# Altium schematic sheet Power.SchDoc
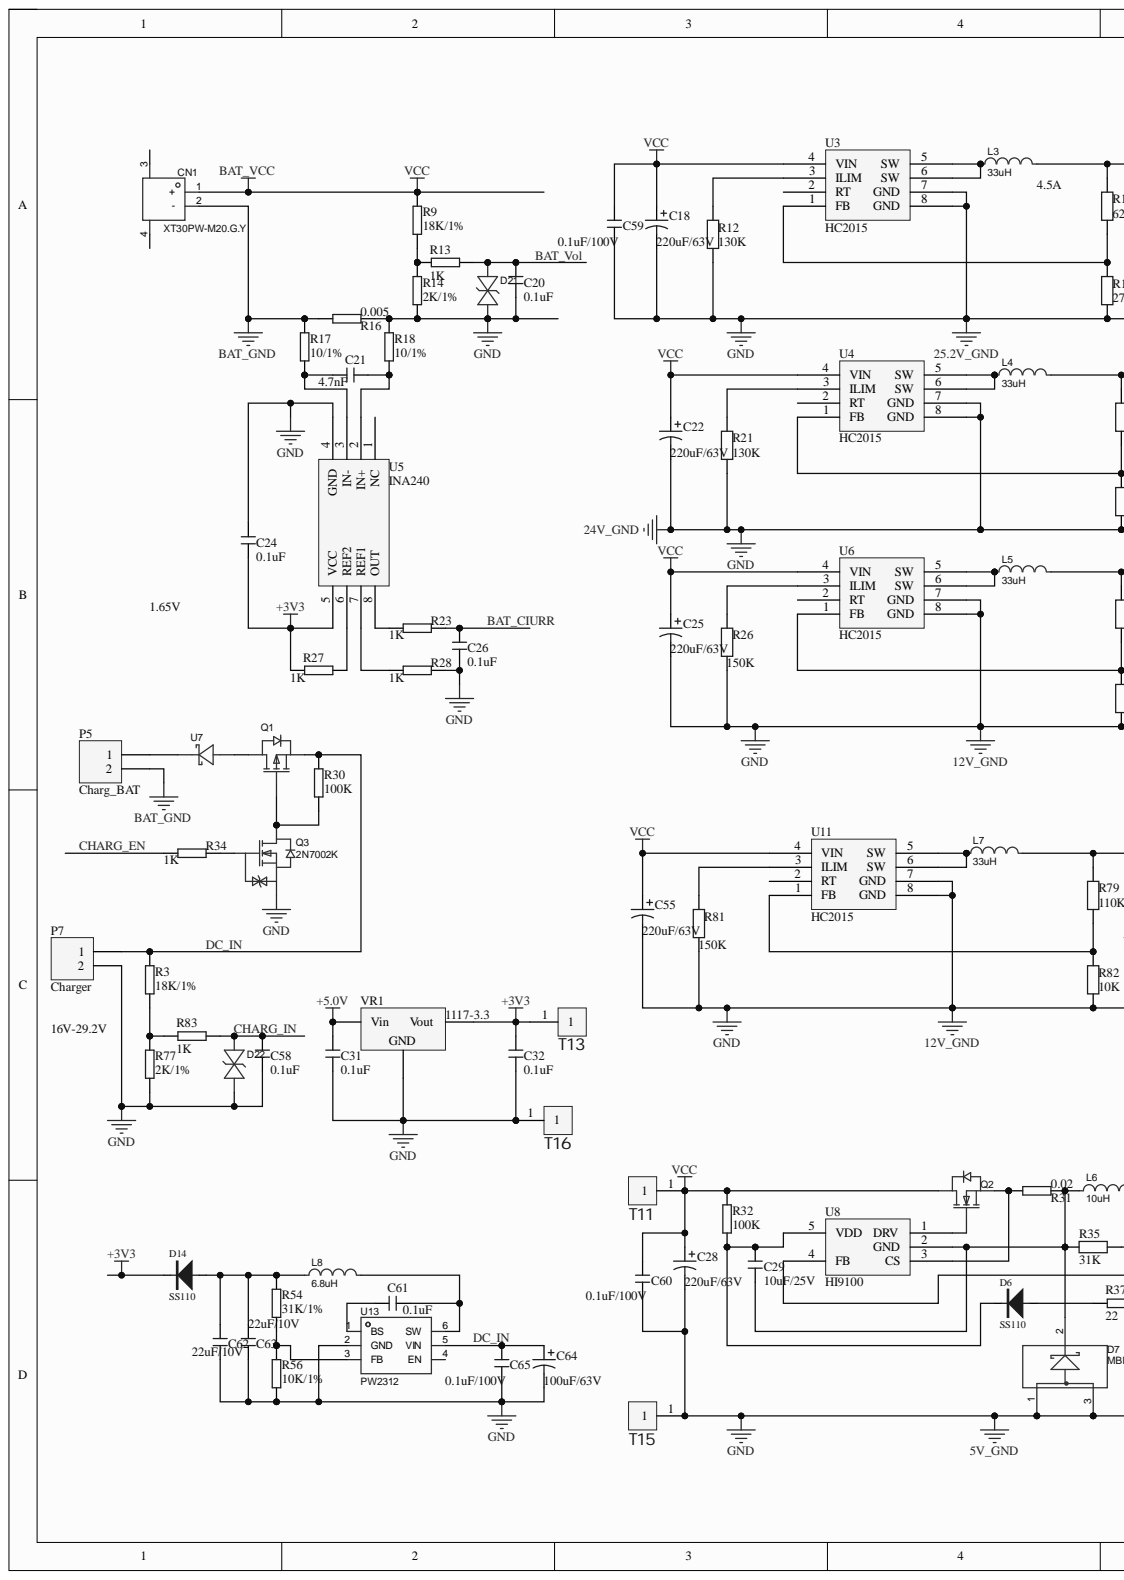
[diagram p0: Power.SchDoc, left]
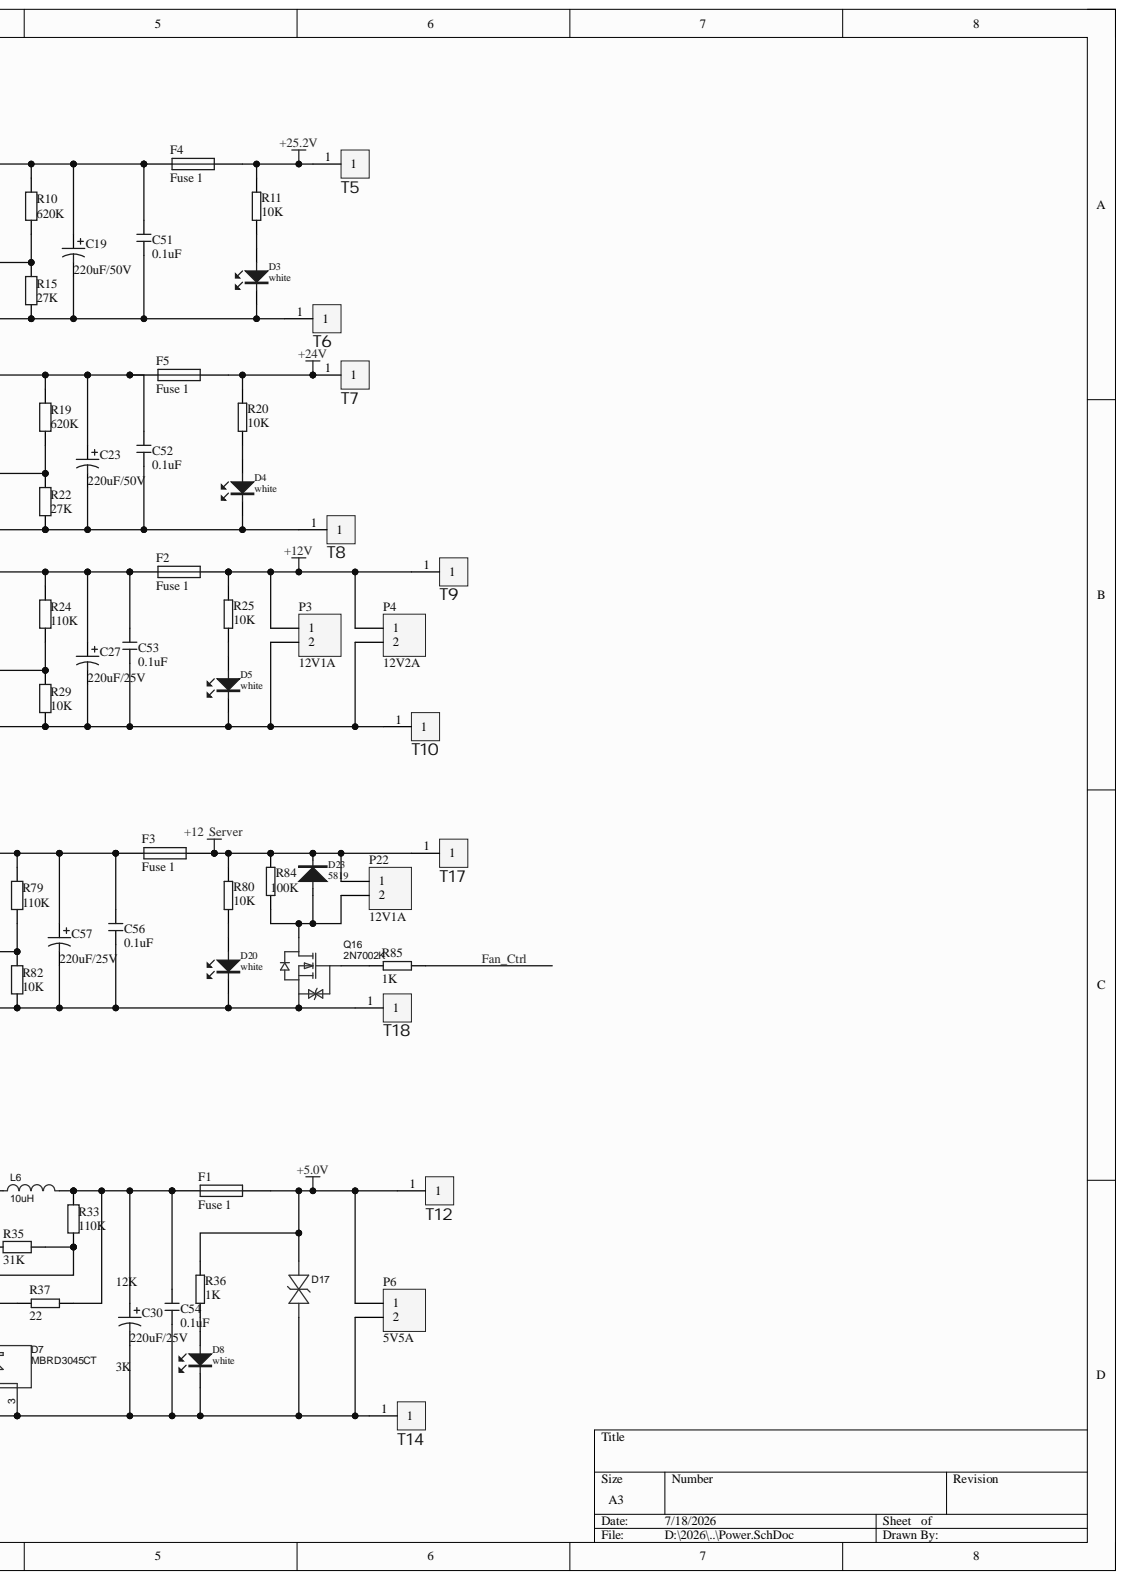
[diagram p1: Power.SchDoc, right]

## Parts
C18  value 220uF/63V  [CM F(10*10.2)]  (hidden comment: 100uF/16V)
C19  value 220uF/50V  [CT 8*7 - C]  (hidden comment: 100uF/16V)
C20  0.1uF  [C 0603_L]
C21  4.7nF  [C 0603_L]
C22  value 220uF/63V  [CM F(10*10.2)]  (hidden comment: 100uF/16V)
C23  value 220uF/50V  [CT 8*7 - C]  (hidden comment: 100uF/16V)
C24  0.1uF  [C 0603_L]
C25  value 220uF/63V  [CM F(10*10.2)]  (hidden comment: 100uF/16V)
C26  0.1uF  [C 0603_L]
C27  value 220uF/25V  [CT 8*7 - C]  (hidden comment: 100uF/16V)
C28  value 220uF/63V  [CM F(10*10.2)]  (hidden comment: 100uF/16V)
C29  10uF/25V  [C 0603_L]
C30  value 220uF/25V  [CM D(6.3*7.7)]  (hidden comment: 100uF/16V)
C31  0.1uF  [C 0603_L]
C32  0.1uF  [C 0603_L]
C51  0.1uF  [C 0603_L]
C52  0.1uF  [C 0603_L]
C53  0.1uF  [C 0603_L]
C54  0.1uF  [C 0603_L]
C55  value 220uF/63V  [CM F(10*10.2)]  (hidden comment: 100uF/16V)
C56  0.1uF  [C 0603_L]
C57  value 220uF/25V  [CT 8*7 - C]  (hidden comment: 100uF/16V)
C58  0.1uF  [C 0603_L]
C59  0.1uF/100V  [C 0603_L]
C60  0.1uF/100V  [C 0603_L]
C61  0.1uF  [C 0603_L]
C62  22uF/10V  [C 0603_L]
C63  22uF/10V  [C 0603_L]
C64  value 100uF/63V  [CM E(8*10.2)]  (hidden comment: 100uF/16V)
C65  0.1uF/100V  [C 0603_L]
CN1  XT30PW-M20.G.Y  [XT30-TH_XT30PW-M20.G.Y]
D3  white  [L 0603]
D4  white  [L 0603]
D5  white  [L 0603]
D6  SS110  [SOD-123]
D7  MBRD3045CT  [TO-252-2_L6.6-W6.1-P4.57-LS9.9-TL-CW]
D8  white  [L 0603]
D14  SS110  [SOD-123]
D17  PSD03C-LF-T7  [SMB]  (value not drawn)
D20  white  [L 0603]
D21  PSD03C-LF-T7  [SOD-323_L1.8-W1.3-LS2.5-BI]  (value not drawn)
D22  PSD03C-LF-T7  [SOD-323_L1.8-W1.3-LS2.5-BI]  (value not drawn)
D23  5819  [SOD-123]
F1  Fuse 1  [R 1812_L]
F2  Fuse 1  [R 1812_L]
F3  Fuse 1  [R 1812_L]
F4  Fuse 1  [R 1812_L]
F5  Fuse 1  [R 1812_L]
L3  33uH  [IND-SMD_L7.8-W7.0_SLF0705]
L4  33uH  [IND-SMD_L7.8-W7.0_SLF0705]
L5  33uH  [IND-SMD_L7.8-W7.0_SLF0705]
L6  10uH  [IND-SMD_L7.8-W7.0_SLF0705]
L7  33uH  [IND-SMD_L7.8-W7.0_SLF0705]
L8  6.8uH  [CD32]
P3  12V1A  [XH2.54-LS-2P]
P4  12V2A  [XH2.54-LS-2P]
P5  Charg_BAT  [PH2.0-LS-2P]
P6  5V5A  [XH2.54-LS-2P]
P7  Charger  [XH2.54-LS-2P]
P22  12V1A  [XH2.54-LS-2P]
Q1  50P04  [TO-252-2_L6.6-W6.1-P4.57-LS9.9-BR-CW]  (value not drawn)
Q2  PTD50N06  [TO-252-2_L6.6-W6.1-P4.57-LS9.9-BR-CW]  (value not drawn)
Q3  2N7002K  [SOT-23_L2.9-W1.3-P1.90-LS2.4-BR]
Q16  2N7002K  [SOT-23_L2.9-W1.3-P1.90-LS2.4-BR]
R3  18K/1%  [R 0603_L]
R9  18K/1%  [R 0603_L]
R10  value 620K  [R 0603_L]  (hidden comment: 100R)
R11  10K  [R 0603_L]
R12  value 130K  [R 0603_L]  (hidden comment: 100R)
R13  1K  [R 0603_L]
R14  2K/1%  [R 0603_L]
R15  value 27K  [R 0603_L]  (hidden comment: 100R)
R16  0.005  [R 2512_M]
R17  10/1%  [R 0603_L]
R18  10/1%  [R 0603_L]
R19  value 620K  [R 0603_L]  (hidden comment: 100R)
R20  10K  [R 0603_L]
R21  value 130K  [R 0603_L]  (hidden comment: 100R)
R22  value 27K  [R 0603_L]  (hidden comment: 100R)
R23  1K  [R 0603_L]
R24  value 110K  [R 0603_L]  (hidden comment: 100R)
R25  10K  [R 0603_L]
R26  value 150K  [R 0603_L]  (hidden comment: 100R)
R27  1K  [R 0603_L]
R28  1K  [R 0603_L]
R29  value 10K  [R 0603_L]  (hidden comment: 100R)
R30  100K  [R 0603_L]
R31  0.02  [R 2512_M]
R32  100K  [R 0603_L]
R33  value 110K  [R 0603_L]  (hidden comment: 100R)
R34  1K  [R 0603_L]
R35  value 31K  [R 0603_L]  (hidden comment: 100R)
R36  1K  [R 0603_L]
R37  22  [R 0603_L]
R54  31K/1%  [R 0603_L]
R56  10K/1%  [R 0603_L]
R77  2K/1%  [R 0603_L]
R79  value 110K  [R 0603_L]  (hidden comment: 100R)
R80  10K  [R 0603_L]
R81  value 150K  [R 0603_L]  (hidden comment: 100R)
R82  value 10K  [R 0603_L]  (hidden comment: 100R)
R83  1K  [R 0603_L]
R84  100K  [R 0603_L]
R85  1K  [R 0603_L]
T5  (no value)  [TestPin_2x3]
T6  (no value)  [TestPin_2x3]
T7  (no value)  [TestPin_2x3]
T8  (no value)  [TestPin_2x3]
T9  (no value)  [TestPin_2x3]
T10  (no value)  [TestPin_2x3]
T11  (no value)  [TestPin_2x3]
T12  (no value)  [TestPin_2x3]
T13  (no value)  [TestPin_2x3]
T14  (no value)  [TestPin_2x3]
T15  (no value)  [TestPin_2x3]
T16  (no value)  [TestPin_2x3]
T17  (no value)  [TestPin_2x3]
T18  (no value)  [TestPin_2x3]
U3  HC2015  [SOP8_N]
U4  HC2015  [SOP8_N]
U5  INA240  [TSSOP8_L]
U6  HC2015  [SOP8_N]
U7  SK84  [SMC_L6.9-W5.9-LS7.9-RD]  (value not drawn)
U8  HI9100  [SOT23-5]
U11  HC2015  [SOP8_N]
U13  PW2312  [SOT23-6L]
VR1  1117-3.3  [SOT-223]

## Nets
5V_GND: C18.2 C19.2 C20.2 C22.2 C23.2 C24.2 C25.2 C26.2 C27.2 C28.2 C30.2 C31.1 C31.2 C32.2 C51.2 C52.2 C53.2 C54.2 C55.2 C56.2 C57.2 C58.2 C59.2 C60.2 C62.1 C63.1 C64.2 C65.2 D3.2 D4.2 D5.2 D7.1 D7.3 D8.2 D17.1 D17.2 D20.2 D21.1 D22.1 F1.2 P3.2 P4.2 P6.1 P6.2 P7.2 Q3.2 Q16.2 R12.2 R14.2 R15.2 R16.1 R18.1 R21.2 R22.2 R26.2 R28.2 R29.2 R36.1 R56.1 R77.1 R81.2 R82.2 T6.1 T8.1 T10.1 T12.1 T14.1 T15.1 T16.1 T18.1 U3.7(GND) U3.8(GND) U4.7(GND) U4.8(GND) U5.4(GND) U6.7(GND) U6.8(GND) U11.7(GND) U11.8(GND) U13.2(GND) VR1.1 VR1.2
+3V3: C24.1 C32.1 D14.2 R27.2 T13.1 U5.5(VCC) VR1.3
+12  Server: D23.2 F3.2 P22.1 R80.1 R84.1 T17.1
+12V: F2.2 P3.1 P4.1 R25.1 T9.1
+24V: F5.2 R20.1 T7.1
+25.2V: F4.2 R11.1 T5.1
BAT_GND: CN1.2(-) P5.2 R16.2 R17.1
BAT_VCC: C18.1 C22.1 C25.1 C28.1 C55.1 C59.1 C60.1 CN1.1(+) Q2.2 R9.1 R32.1 T11.1 U3.4(VIN) U4.4(VIN) U6.4(VIN) U11.4(VIN)
BAT_CIURR: C26.1 R23.2
BAT_Vol: C20.1 D21.2 R13.2
CHARG_EN: R34.1
CHARG_IN: C58.1 D22.2 R83.2
DC_IN: C64.1 C65.1 P7.1 Q1.3 R3.2 R30.1 U13.5(VIN)
Fan_Ctrl: R85.1
NetC19_1: C19.1 C51.1 F4.1 L3.2 R10.2
NetC21_1: C21.1 R17.2 U5.3(IN-)
NetC21_2: C21.2 R18.2 U5.2(IN+)
NetC23_1: C23.1 C52.1 F5.1 L4.2 R19.2
NetC27_1: C27.1 C53.1 F2.1 L5.2 R24.2
NetC29_1: C29.1 D6.2 R32.2 U8.5(VDD)
NetC29_2: C29.2 D7.2 L6.1 R31.1 R35.2 U8.2(GND)
NetC30_1: C30.1 C54.1 F1.1 L6.2 R33.2 R37.2
NetC56_1: C56.1 C57.1 F3.1 L7.2 R79.2
NetC61_1: C61.1 U13.1(BS)
NetC61_2: C61.2 L8.2 U13.6(SW)
NetC62_2: C62.2 C63.2 D14.1 L8.1 R54.2
NetD3_1: D3.1 R11.2
NetD4_1: D4.1 R20.2
NetD5_1: D5.1 R25.2
NetD6_1: D6.1 R37.1
NetD8_1: D8.1 R36.2
NetD20_1: D20.1 R80.2
NetD23_1: D23.1 P22.2 Q16.3 R84.2
NetL3_1: L3.1 U3.5(SW) U3.6(SW)
NetL4_1: L4.1 U4.5(SW) U4.6(SW)
NetL5_1: L5.1 U6.5(SW) U6.6(SW)
NetL7_1: L7.1 U11.5(SW) U11.6(SW)
NetP5_1: P5.1 U7.1
NetQ1_1: Q1.1 Q3.3 R30.2
NetQ1_2: Q1.2 U7.2
NetQ2_1: Q2.1 U8.1(DRV)
NetQ2_3: Q2.3 R31.2 U8.3(CS)
NetQ3_1: Q3.1 R34.2
NetQ16_1: Q16.1 R85.2
NetR3_1: R3.1 R77.2 R83.1
NetR9_2: R9.2 R13.1 R14.1
NetR10_1: R10.1 R15.1 U3.1(FB)
NetR12_1: R12.1 U3.3(ILIM)
NetR19_1: R19.1 R22.1 U4.1(FB)
NetR21_1: R21.1 U4.3(ILIM)
NetR23_1: R23.1 U5.8(OUT)
NetR24_1: R24.1 R29.1 U6.1(FB)
NetR26_1: R26.1 U6.3(ILIM)
NetR27_1: R27.1 U5.6(REF2)
NetR28_1: R28.1 U5.7(REF1)
NetR33_1: R33.1 R35.1 U8.4(FB)
NetR54_1: R54.1 R56.2 U13.3(FB)
NetR79_1: R79.1 R82.1 U11.1(FB)
NetR81_1: R81.1 U11.3(ILIM)

Not connected: CN1 3 4; U3 2(RT); U4 2(RT); U5 1(NC); U6 2(RT); U11 2(RT); U13 4(EN)

## Library data of the parts
C18 C19 C22 C23 C25 C27 C28 C30 C55 C57 C64 = Cap Pol1: Polarized Capacitor (Radial); Component Kind: Standard; LatestRevisionDate: 17-Jul-2002; LatestRevisionNote: Re-released for DXP Platform.; Library Name: Miscellaneous Devices.IntLib; PackageReference: RB7.6-15; Published: 8-Jun-2000; Signal Integrity: Cap Pol; Simulation: CAP; Publisher: Altium Limited
C20 C21 C24 C26 C29 C31 C32 C51-C54 C56 C58-C63 C65 = C: 贴片电容; Component Kind: Standard; Library Name: GS_SchLib.SchLib
CN1 = XT30PW-M20.G.Y: Manufacturer: AMASS(艾迈斯); AddInBom: yes; ModelId: 92b4aee0a81e497d8106170778d86533聨0819f05c4eef4c71ace90d822a990e87; ModelTransform: 523.621,549.2115,0,0,0,0,0,42.126,-118.11; Component Kind: Standard; ConvertToPcb: yes; Device: XT30PW-M20.G.Y; FootprintName: XT30-TH_XT30PW-M20.G.Y; JLCPCB Part Class: Extended Part; LCSC Part Name: AMASS(艾迈斯)航模插头电调机插座锂电池连接器 PCB板卧式 金 黄 公头XT30PW-M20.G.Y; ManufacturerPart: XT30PW-M20.G.Y; ModelName: XT30-TH_XT30PW-M20.G.Y; Supplier: LCSC; Supplier Footprint: -; SupplierPart: C30170175; Symbol: XT30PW-M20.G.Y
D3-D5 D8 D20 = LED: Component Kind: Standard; Library Name: HX_General.IntLib
D6 D14 D23 = DIODE: Component Kind: Standard; Description: Diode; Library Name: HX_General.IntLib
D7 = MBRD360T4G: 直流反向耐压(Vr):60V;平均整流电流(Io):3A;正向压降(Vf):600mV@3A;; Manufacturer: onsemi(安森美); AddInBom: yes; Average Rectified Current (Io): 3A; ModelId: ce57f84d66384067af8a68020b6de06d聨0819f05c4eef4c71ace90d822a990e87; ModelName: TO-252-2L-3P_L6.6-W6.1-H2.3-LS10.1-P4.6; ModelTransform: 259.842,390.52442,0,270,0,0,38.027,-0.598,0; Reverse Voltage (Vr): 60V; Component Kind: Standard; ConvertToPcb: yes; Datasheet: https://atta.szlcsc.com/upload/public/pdf/source/20121229/1457706573761.pdf; Device: MBRD360T4G; FootprintName: TO-252-2_L6.6-W6.1-P4.57-LS9.9-TL-CW; Forward Voltage (Vf@If): 600mV@3A; JLCPCB Part Class: Extended Part; LCSC Part Name: 60V 3A 600mV@3A; ManufacturerPart: MBRD360T4G; Supplier: LCSC; Supplier Footprint: TO-252-2(DPAK); SupplierPart: C10667; Symbol: MBRD360T4G
D17 D21 D22 = PSD03C-LF-T7: 极性:双向;反向截止电压(Vrwm):3.3V;击穿电压(最小值):4V;最大钳位电压:7V;; Manufacturer: PROTEK; AddInBom: yes; Breakdown Voltage (Min): 4V; Maximum Clamping Voltage: 7V; ModelId: e9dd044ee7bf4221b3b795eb56bce7c0聨0819f05c4eef4c71ace90d822a990e87; ModelName: SOD-323_L1.7-W1.3-H1.1-LS2.5; ModelTransform: 98.9,51.2,0,0,0,0,0,0,0; Polarity: 双向; Reverse Stand-Off Voltage (Vrwm): 3.3V; Component Kind: Standard; ConvertToPcb: yes; Datasheet: https://atta.szlcsc.com/upload/public/pdf/source/20171026/C139300_15089856513231059587.pdf; Device: PSD03C-LF-T7; FootprintName: SOD-323_L1.8-W1.3-LS2.5-BI; JLCPCB Part Class: Extended Part; LCSC Part Name: 双向 3.3V; ManufacturerPart: PSD03C-LF-T7; Supplier: LCSC; Supplier Footprint: SOD-323; SupplierPart: C139300; Symbol: PSD03C-LF-T7
F1-F5 = Fuse 1: Fuse; Component Kind: Standard; LatestRevisionDate: 17-Jul-2002; LatestRevisionNote: Re-released for DXP Platform.; Library Name: Miscellaneous Devices.IntLib; PackageDescription: Thru-Hole; 2 Leads; PackageReference: PIN-W2/E2.8; Published: 8-Jun-2000; Simulation: FUSE; Publisher: Altium Limited
L3-L5 L7 = SM7850-330MT: 电感值:33uH;精度:±20%;额定电流:1.2A;直流电阻(DCR):130mΩ;; Manufacturer: SXN(顺翔诺); AddInBom: yes; DC Resistance (DCR): 130mΩ; ModelId: 9a11ed34b68f4e34b6837b974c90a0dc聨0819f05c4eef4c71ace90d822a990e87; ModelName: IND-SMD_L7.8-W7.0-H5.0-0.705; ModelTransform: 275.59,302.52829,0,90,0,0,0,0,0; Rated Current: 1.2A; Tolerance: ±20%; Component Kind: Standard; ConvertToPcb: yes; Datasheet: https://atta.szlcsc.com/upload/public/pdf/source/20210810/DE909F839CF261ABDAA312DFDF4FA1A6.pdf; Device: SM7850-330MT; FootprintName: IND-SMD_L7.8-W7.0_SLF0705; JLCPCB Part Class: Extended Part; LCSC Part Name: 33uH ±20% 1.2A 130mΩ; ManufacturerPart: SM7850-330MT; Supplier: LCSC; Supplier Footprint: SMD; SupplierPart: C9183; Symbol: SM7850-330MT; Value: 33uH
L6 L8 = SM7850-330MT: 电感值:33uH;精度:±20%;额定电流:1.2A;直流电阻(DCR):130mΩ;; Manufacturer: SXN(顺翔诺); AddInBom: yes; DC Resistance (DCR): 130mΩ; ModelId: 9a11ed34b68f4e34b6837b974c90a0dc聨0819f05c4eef4c71ace90d822a990e87; ModelName: IND-SMD_L7.8-W7.0-H5.0-0.705; ModelTransform: 275.59,302.52829,0,90,0,0,0,0,0; Rated Current: 1.2A; Tolerance: ±20%; Value: 33uH; Component Kind: Standard; ConvertToPcb: yes; Datasheet: https://atta.szlcsc.com/upload/public/pdf/source/20210810/DE909F839CF261ABDAA312DFDF4FA1A6.pdf; Device: SM7850-330MT; FootprintName: IND-SMD_L7.8-W7.0_SLF0705; JLCPCB Part Class: Extended Part; LCSC Part Name: 33uH ±20% 1.2A 130mΩ; ManufacturerPart: SM7850-330MT; Supplier: LCSC; Supplier Footprint: SMD; SupplierPart: C9183; Symbol: SM7850-330MT
P3-P7 P22 = Header 2: Header, 2-Pin; Component Kind: Standard; LatestRevisionDate: 17-Jul-2002; LatestRevisionNote: Re-released for DXP Platform.; Library Name: Miscellaneous Connectors.IntLib; Signal Integrity: Connector; Publisher: Altium Limited
Q1 = 50P04: 数量:1个P沟道;漏源电压(Vdss):40V;连续漏极电流(Id):52A;导通电阻(RDS(on)):13mΩ@10V;耗散功率(Pd):45W;阈值电压(Vgs(th)):2.5V;; Manufacturer: HL(富海微); AddInBom: yes; Current - Continuous Drain(Id): 52A; Drain to Source Voltage: 40V; Gate Charge(Qg): 68nC@10V; Gate Threshold Voltage (Vgs(th)): 2.5V; Input Capacitance(Ciss): 3.8nF; ModelId: ce57f84d66384067af8a68020b6de06d聨0819f05c4eef4c71ace90d822a990e87; ModelName: TO-252-2L-3P_L6.6-W6.1-H2.3-LS10.1-P4.6; ModelTransform: 259.842,390.52442,0,90,0,0,-39.39,0,0; Number: 1个P沟道; Output Capacitance(Coss): 329pF; Pd - Power Dissipation: 45W; Reverse Transfer Capacitance (Crss@Vds): 289pF; Symbol: 50P04_C18164393; Type: P沟道; Component Kind: Standard; ConvertToPcb: yes; Datasheet: https://item.szlcsc.com/datasheet/50P04/19305345.html; Device: 50P04; FootprintName: TO-252-2_L6.6-W6.1-P4.57-LS9.9-BR-CW; JLCPCB Part Class: Extended Part; LCSC Part Name: 1个P沟道 耐压:40V 电流:52A; ManufacturerPart: 50P04; Operating Temperature: -55℃~+150℃; RDS(on): 13mΩ@10V; Supplier: LCSC; Supplier Footprint: TO-252; SupplierPart: C18164393
Q2 = PTD50N06: 类型:N沟道;漏源电压(Vdss):60V;连续漏极电流(Id):50A;功率(Pd):85W;导通电阻(RDS(on)@Vgs,Id):20mΩ@10V,20A;阈值电压(Vgs(th)@Id):2.5V@250μA;; Manufacturer: PUOLOP(迪浦); AddInBom: yes; Continuous Drain Current (Id): 50A; Drain Source Voltage (Vdss): 60V; ModelId: 3eac9db95c5b4fb0ba6d50c62f0c8747聨0819f05c4eef4c71ace90d822a990e87; ModelName: TO-252-3_L6.5-W6.1-H2.3-LS10.0-P4.58; ModelTransform: 255.9,390.5,0,90,0,0,-43.2,0,0; Power Dissipation (Pd): 85W; Type: N沟道; Component Kind: Standard; ConvertToPcb: yes; Datasheet: https://atta.szlcsc.com/upload/public/pdf/source/20200102/C479046_5DD356377C59B8CD65B8916FDA112325.pdf; Device: PTD50N06; Drain Source On Resistance (RDS(on)@Vgs,Id): 20mΩ@10V,20A; FootprintName: PG-TO252-3_L6.3-W6.5-P4.57-LS10.0-BR-CW; Gate Threshold Voltage (Vgs(th)@Id): 2.5V@250μA; JLCPCB Part Class: Extended Part; LCSC Part Name: N沟道 60V 50A; ManufacturerPart: PTD50N06; Supplier: LCSC; Supplier Footprint: TO-252; SupplierPart: C479046; Symbol: PTD50N06
Q3 Q16 = 2N7002K: 数量:1个N沟道;漏源电压(Vdss):60V;连续漏极电流(Id):300mA;导通电阻(RDS(on)):2Ω@10V;耗散功率(Pd):350mW;阈值电压(Vgs(th)):2.5V@1mA;; Manufacturer: EIC; AddInBom: yes; Current - Continuous Drain(Id): 300mA; Drain to Source Voltage: 60V; Gate Charge(Qg): 800pC@4.5V; Input Capacitance(Ciss): 50pF; ModelId: c62791f0466c4ae2a892c056b845b0ca聨0819f05c4eef4c71ace90d822a990e87; ModelName: SOT-23-3P_L2.9-W1.3-H1.0-LS2.4-P0.95; ModelTransform: 98.64468,114.173,0,180,0,0,0.001,-0.002,0; Number: 1个N沟道; Output Capacitance(Coss): 25pF; Pd - Power Dissipation: 350mW; RDS(on): 2Ω@10V; Reverse Transfer Capacitance (Crss@Vds): 5pF; Symbol: 2N7002K_C2978790; Type: N沟道; Component Kind: Standard; ConvertToPcb: yes; Datasheet: https://item.szlcsc.com/datasheet/2N7002K/3393615.html; Device: 2N7002K; FootprintName: SOT-23_L2.9-W1.3-P1.90-LS2.4-BR; Gate Threshold Voltage (Vgs(th)): 2.5V@1mA; JLCPCB Part Class: Extended Part; LCSC Part Name: N沟道增强型MOSFET,电流:300mA,耐压:60V; ManufacturerPart: 2N7002K; Operating Temperature: -55℃~+150℃; Supplier: LCSC; Supplier Footprint: SOT-23; SupplierPart: C2978790
R3 R9 R11 R13 R14 R16-R18 R20 R23 R25 R27 R28 R30-R32 R34 R36 R37 R54 R56 R77 R80 R83-R85 = RES3-SMD: SMD筿; Component Kind: Standard; Library Name: Orson SCH.SCHLIB
R10 R12 R15 R19 R21 R22 R24 R26 R29 R33 R35 R79 R81 R82 = Res2: Resistor; Manufacturer: Generic Components; Class: Passive; Component Kind: Standard; Published: 08/06/2000; SubClass: Resistor; Publisher: Altium Hobart Technology Centre
T5-T18 = CON1: Connector; Component Kind: Standard
U3 U4 U6 U11 = Component_2: Component Kind: Standard; Library Name: Schlib1.SchLib
U5 U8 = Component_1: Component Kind: Standard; Library Name: Schlib1.SchLib
U7 = SK84: 二极管配置:独立式;正向压降(Vf):550mV@8A;直流反向耐压(Vr):40V;整流电流:8A;; Manufacturer: CJ(江苏长电/长晶); AddInBom: yes; Current - Rectified: 8A; Diode Configuration: 独立式; ModelId: 181deed3e51b4528a83dd624c23bafda聨0819f05c4eef4c71ace90d822a990e87; ModelTransform: 314.96,236.22,0,0,0,0,0,0,0; Non-Repetitive Peak Forward Surge Current: 200A; Operating Junction Temperature Range: -55℃~+125℃; Reverse Leakage Current (Ir): 500uA@40V; Symbol: SK84_C2961222; Voltage - DC Reverse(Vr): 40V; Component Kind: Standard; ConvertToPcb: yes; Datasheet: https://item.szlcsc.com/datasheet/SK84/3349420.html; Device: SK84; FootprintName: SMC_L6.9-W5.9-LS7.9-RD; JLCPCB Part Class: Extended Part; LCSC Part Name: 电压:40V 电流:8A; ManufacturerPart: SK84; ModelName: SMC_L6.9-W5.9-LS7.9-RD; Supplier: LCSC; Supplier Footprint: SMCG; SupplierPart: C2961222; Voltage - Forward(Vf@If): 550mV@8A
U13 = PW2312: AddInBom: yes; ModelId: 18da8f6c14a34920b3e136b5c401a119聨0819f05c4eef4c71ace90d822a990e87; ModelName: SOT-23-6_L2.9-W1.6-H1.5-LS2.8-P0.95; ModelTransform: 110.236,113.364,0,90,0,0,0.0005,0.0005,0; Component Kind: Standard; ConvertToPcb: yes; Device: PW2312; FootprintName: SOT-23-6_L2.9-W1.6-P0.95-LS2.8-BL; JLCPCB Part Class: Extended Part; LCSC Part Name: PW2312; ManufacturerPart: PW2312; Supplier: LCSC; Supplier Footprint: SOT-23-6; SupplierPart: C2837114; Symbol: PW2312
VR1 = Volt Reg: Voltage Regulator; Component Kind: Standard; LatestRevisionDate: 29-May-2009; LatestRevisionNote: IPC-7351 Footprint Added.; Library Name: Miscellaneous Devices.IntLib; PackageDescription: 3-Pin Transistor Outline Package 2.54 mm Pitch, 15.1 mm Lead Span; PackageReference: D2PAK; Published: 24-Mar-1999; Publisher: Altium Limited
